# Altium schematic sheet [04] - MCU ESP32.SchDoc("[04] - MCU ESP32")
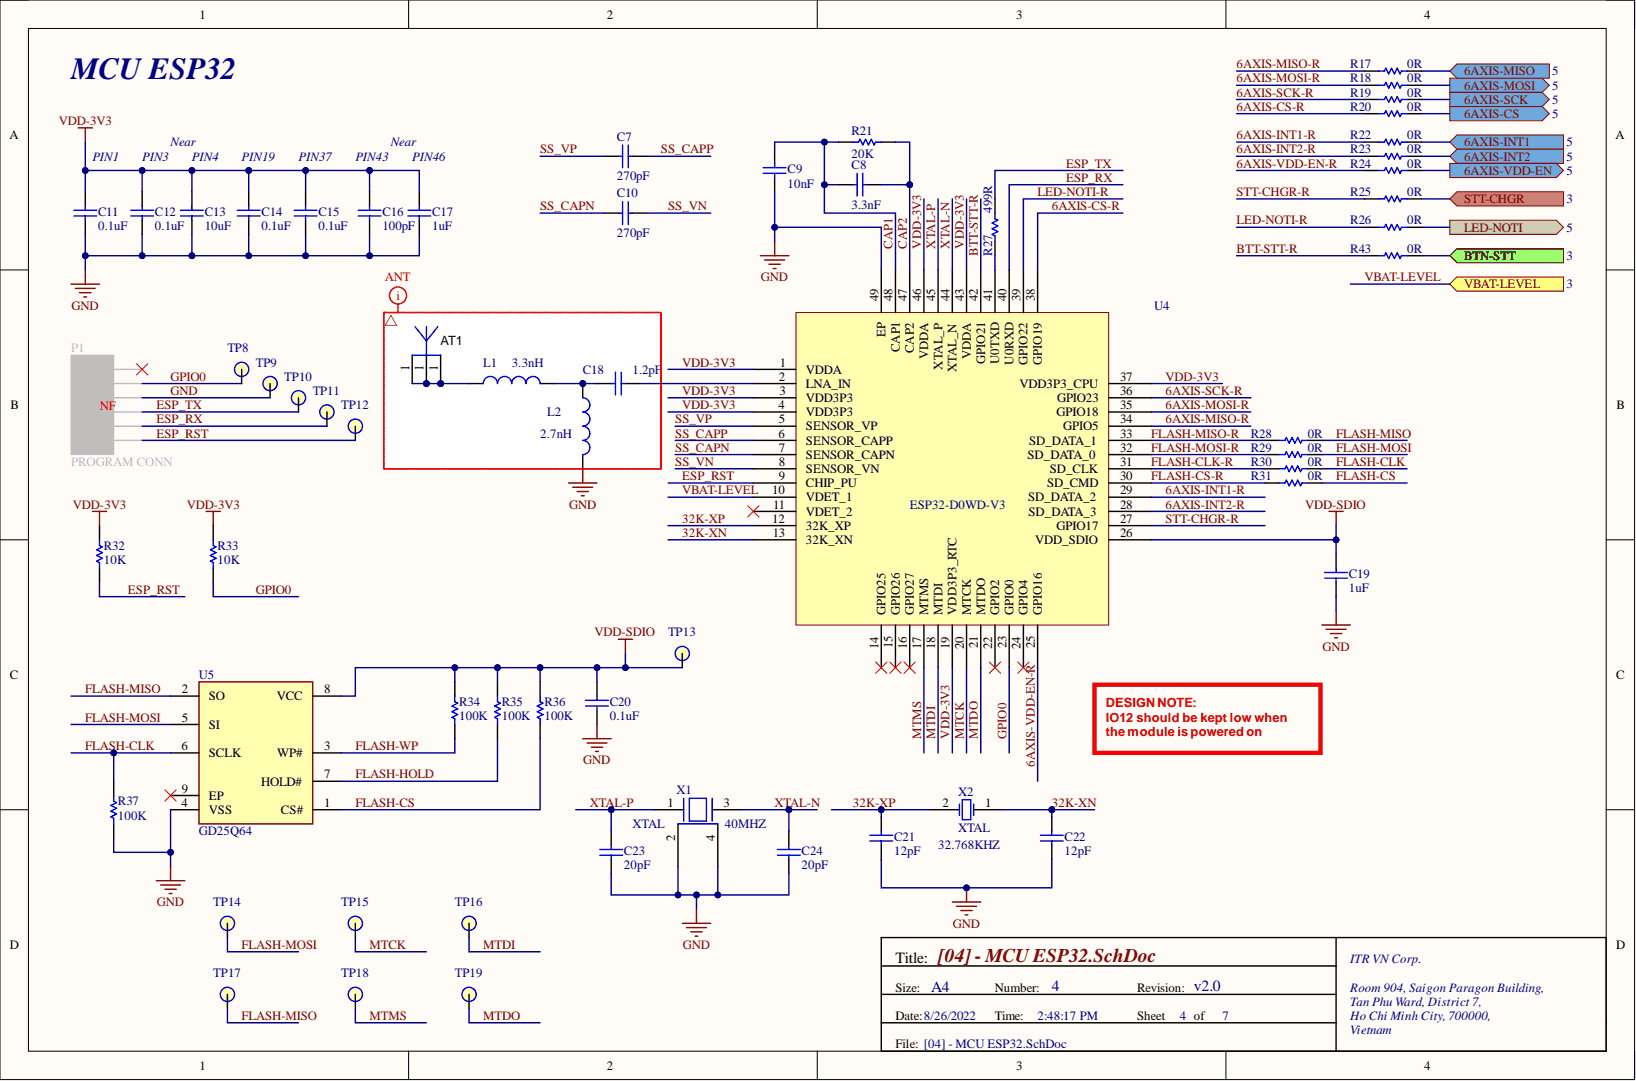
[diagram p0: [04] - MCU ESP32.SchDoc]

## Parts
AT1  2450AT18B100E  [ANT_2450AT18B100E]  (value not drawn)
C7  value 270pF  Capacitance: 270pF  [CAPC1005X55_0402]  (hidden comment: Capacitor)
C8  value 3.3nF  [CAPC1005X55_0402]  (hidden comment: Capacitor)
C9  value 10nF  [CAPC1005X55_0402]  (hidden comment: Capacitor)
C10  value 270pF  Capacitance: 270pF  [CAPC1005X55_0402]  (hidden comment: Capacitor)
C11  value 0.1uF  [CAPC1005X55_0402]  (hidden comment: Capacitor)
C12  value 0.1uF  [CAPC1005X55_0402]  (hidden comment: Capacitor)
C13  value 10uF  [CAPC1608X80_0603]  (hidden comment: Capacitor)
C14  value 0.1uF  [CAPC1005X55_0402]  (hidden comment: Capacitor)
C15  value 0.1uF  [CAPC1005X55_0402]  (hidden comment: Capacitor)
C16  value 100pF  Capacitance: 100pF  [CAPC1005X55_0402]  (hidden comment: Capacitor)
C17  value 1uF  Capacitance: 1uF  [CAPC1005X55_0402]  (hidden comment: Capacitor)
C18  value 1.2pF  Capacitance: 1.2pF  [CAPC1005X55_0402]  (hidden comment: Capacitor)
C19  value 1uF  Capacitance: 1uF  [CAPC1005X55_0402]  (hidden comment: Capacitor)
C20  value 0.1uF  [CAPC1005X55_0402]  (hidden comment: Capacitor)
C21  value 12pF  Capacitance: 12pF  [CAPC1005X55_0402]  (hidden comment: Capacitor)
C22  value 12pF  Capacitance: 12pF  [CAPC1005X55_0402]  (hidden comment: Capacitor)
C23  value 20pF  Capacitance: 20pF  [CAPC1005X55_0402]  (hidden comment: Capacitor)
C24  value 20pF  Capacitance: 20pF  [CAPC1005X55_0402]  (hidden comment: Capacitor)
L1  value 3.3nH  Inductance: 3.3nH  [INDC1005X50_0402]  (hidden comment: Inductor)
L2  value 2.7nH  Inductance: 2.7nH  [INDC1005X50_0402]  (hidden comment: Inductor)
P1  PROGRAM CONN  [Molex_530470610]
R17  value 0R  [RESC1005X40_0402]  (hidden comment: Resistor)
R18  value 0R  [RESC1005X40_0402]  (hidden comment: Resistor)
R19  value 0R  [RESC1005X40_0402]  (hidden comment: Resistor)
R20  value 0R  [RESC1005X40_0402]  (hidden comment: Resistor)
R21  value 20K  [RESC1005X40_0402]  (hidden comment: Resistor)
R22  value 0R  [RESC1005X40_0402]  (hidden comment: Resistor)
R23  value 0R  [RESC1005X40_0402]  (hidden comment: Resistor)
R24  value 0R  [RESC1005X40_0402]  (hidden comment: Resistor)
R25  value 0R  [RESC1005X40_0402]  (hidden comment: Resistor)
R26  value 0R  [RESC1005X40_0402]  (hidden comment: Resistor)
R27  value 499R  Resistance: 499R  [RESC1005X40_0402]  (hidden comment: Resistor)
R28  value 0R  [RESC1005X40_0402]  (hidden comment: Resistor)
R29  value 0R  [RESC1005X40_0402]  (hidden comment: Resistor)
R30  value 0R  [RESC1005X40_0402]  (hidden comment: Resistor)
R31  value 0R  [RESC1005X40_0402]  (hidden comment: Resistor)
R32  value 10K  [RESC1005X40_0402]  (hidden comment: Resistor)
R33  value 10K  [RESC1005X40_0402]  (hidden comment: Resistor)
R34  value 100K  [RESC1005X40_0402]  (hidden comment: Resistor)
R35  value 100K  [RESC1005X40_0402]  (hidden comment: Resistor)
R36  value 100K  [RESC1005X40_0402]  (hidden comment: Resistor)
R37  value 100K  [RESC1005X40_0402]  (hidden comment: Resistor)
R43  value 0R  [RESC1005X40_0402]  (hidden comment: Resistor)
TP8  TP  [TP100]  (no BOM)
TP9  TP  [TP100]  (no BOM)
TP10  TP  [TP100]  (no BOM)
TP11  TP  [TP100]  (no BOM)
TP12  TP  [TP100]  (no BOM)
TP13  TP  [TP100]  (no BOM)
TP14  TP  [TP100]  (no BOM)
TP15  TP  [TP100]  (no BOM)
TP16  TP  [TP100]  (no BOM)
TP17  TP  [TP100]  (no BOM)
TP18  TP  [TP100]  (no BOM)
TP19  TP  [TP100]  (no BOM)
U4  ESP32-D0WD-V3  [ESP32-D0WD-V3]
U5  GD25Q64  [WSON8(6X5)]
X1  XTAL  value 40MHZ  Load Capacitance: 20pF  [XTAL (3.2X2.5)]
X2  XTAL  value 32.768KHZ  [XTAL(2 X 1.2)MM]

## Ports
6AXIS-CS, 6AXIS-INT1, 6AXIS-INT2, 6AXIS-MISO, 6AXIS-MOSI, 6AXIS-SCK, 6AXIS-VDD-EN, BTN-STT, LED-NOTI, STT-CHGR, VBAT-LEVEL

## Nets
VDD-SDIO: C19.2 C20.2 R34.1 R35.1 R36.1 TP13.1 U4.26(VDD_SDIO) U5.8(VCC)
6AXIS-CS: R20.2 ; port 6AXIS-CS
6AXIS-CS-R: R20.1 U4.38(GPIO19)
6AXIS-INT1: R22.2 ; port 6AXIS-INT1
6AXIS-INT1-R: R22.1 U4.29(SD_DATA_2)
6AXIS-INT2: R23.2 ; port 6AXIS-INT2
6AXIS-INT2-R: R23.1 U4.28(SD_DATA_3)
6AXIS-MISO: R17.2 ; port 6AXIS-MISO
6AXIS-MISO-R: R17.1 U4.34(GPIO5)
6AXIS-MOSI: R18.2 ; port 6AXIS-MOSI
6AXIS-MOSI-R: R18.1 U4.35(GPIO18)
6AXIS-SCK: R19.2 ; port 6AXIS-SCK
6AXIS-SCK-R: R19.1 U4.36(GPIO23)
6AXIS-VDD-EN: R24.2 ; port 6AXIS-VDD-EN
6AXIS-VDD-EN-R: R24.1 U4.25(GPIO16)
32K-XN: C22.2 U4.13(32K_XN) X2.1
32K-XP: C21.2 U4.12(32K_XP) X2.2
BTN-STT: R43.2 ; port BTN-STT
BTT-STT-R: R43.1 U4.42(GPIO21)
CAP1: C8.1 C9.2 R21.1 U4.48(CAP1)
CAP2: C8.2 R21.2 U4.47(CAP2)
ESP_RST: P1.1 R32.2 TP12.1 U4.9(CHIP_PU)
ESP_RX: P1.2 TP11.1 U4.40(U0RXD)
ESP_TX: P1.3(NF) R27.2 TP10.1
FLASH-CLK: R30.2 R37.1 U5.6(SCLK)
FLASH-CLK-R: R30.1 U4.31(SD_CLK)
FLASH-CS: R31.2 R36.2 U5.1(CS#)
FLASH-CS-R: R31.1 U4.30(SD_CMD)
FLASH-HOLD: R35.2 U5.7(HOLD#)
FLASH-MISO: R28.2 TP17.1 U5.2(SO)
FLASH-MISO-R: R28.1 U4.33(SD_DATA_1)
FLASH-MOSI: R29.2 TP14.1 U5.5(SI)
FLASH-MOSI-R: R29.1 U4.32(SD_DATA_0)
FLASH-WP: R34.2 U5.3(WP#)
GND: C9.1 C11.1 C12.1 C13.1 C14.1 C15.1 C16.1 C17.1 C19.1 C20.1 C21.1 C22.1 C23.1 C24.1 L2.2 P1.4 R37.2 TP9.1 U4.49(EP) U5.4(VSS) X1.2 X1.4
GPIO0: P1.5 R33.2 TP8.1 U4.23(GPIO0)
LED-NOTI: R26.2 ; port LED-NOTI
LED-NOTI-R: R26.1 U4.39(GPIO22)
MTCK: TP15.1 U4.20(MTCK)
MTDI: TP16.1 U4.18(MTDI)
MTDO: TP19.1 U4.21(MTDO)
MTMS: TP18.1 U4.17(MTMS)
SS_CAPN: C10.1 U4.7(SENSOR_CAPN)
SS_CAPP: C7.2 U4.6(SENSOR_CAPP)
SS_VN: C10.2 U4.8(SENSOR_VN)
SS_VP: C7.1 U4.5(SENSOR_VP)
STT-CHGR: R25.2 ; port STT-CHGR
STT-CHGR-R: R25.1 U4.27(GPIO17)
VBAT-LEVEL: U4.10(VDET_1) ; port VBAT-LEVEL
VDD-3V3: C11.2 C12.2 C13.2 C14.2 C15.2 C16.2 C17.2 R32.1 R33.1 U4.1(VDDA) U4.3(VDD3P3) U4.4(VDD3P3) U4.19(VDD3P3_RTC) U4.37(VDD3P3_CPU) U4.43(VDDA) U4.46(VDDA)
XTAL-N: C24.2 U4.44(XTAL_N) X1.3
XTAL-P: C23.2 U4.45(XTAL_P) X1.1
NetAT1_1: AT1.1 L1.1
NetC18_1: C18.1 U4.2(LNA_IN)
NetC18_2: C18.2 L1.2 L2.1
NetR27_1: R27.1 U4.41(U0TXD)

Not connected: P1 6; U4 11(VDET_2) 14(GPIO25) 15(GPIO26) 16(GPIO27) 22(GPIO2) 24(GPIO4); U5 9(EP)

## Library data of the parts
AT1 = ANTENNA_DN007A: 2.4GHz PCB Antenna.  There is nothing to buy or mount.; Manufacturer 1: Johanson; Bandwidth: 100MHz; Frequency: 2.45GHz; Gain: -0.5dB; Impedance: 50R; Max Frequency: 2.5GHz; Max Power Dissipation: 3W; Number of Bands: 1; Return Loss: -9.5dB; Case/Package: CSMD; Component Kind: Standard; ComponentLink1Description: Datasheet Link; ComponentLink1URL: https://sps06.itg.ti.com/sites/KMS/crossdivteams/altium/docs/ComponentDatasheets/CC26xxEM-7IB_1_0_Layout.pdf; ComponentLink2Description: https://datasheet.ciiva.com/24025/antenna-2450at18b100-242422-24025839.pdf?src-supplier=Mouser; ComponentLink2URL: https://datasheet.ciiva.com/24025/antenna-2450at18b100-242422-24025839.pdf?src-supplier=Mouser; ComponentLink3Description: https://datasheet.ciiva.com/1124/1318299-1124918.pdf?src-supplier=Element14; ComponentLink3URL: https://datasheet.ciiva.com/1124/1318299-1124918.pdf?src-supplier=Element14; Contact Plating: Tin; Height: 0.051inch; Length: 3.2mm; Manufacturer Part Number 1: 2450AT18B100E; Max Operating Temperature: 85°C; Min Operating Temperature: -40°C; Mount: Surface Mount; Package Quantity: 1; Packaging: Tape and Reel; Power Rating: 3W; Pricing 1: 1=0.58000, 10=0.51000, 25=0.47880, 100=0.39090, 250=0.36308, 500=0.30900, 1000=0.24720 (USD); Radiation Hardening: No; REACH SVHC: No SVHC; RoHS: Yes; Stock 1: 269674; Supplier 1: Digi-Key; Supplier Part Number 1: 712-1006-1-ND; Termination: Solder; Width: 1.6mm; Library Name: symreglib-cc2640.SchLib
C7 C10 = Capacitor Semi: CAP CER 270PF 50V X7R 0402; Manufacturer 1: Murata; Manufacturer Part Number 1: GRM155R71H271KA01D; Tolerance: 10%; Case Code (Imperial): 0402; Case Code (Metric): 1005; Case/Package: 0402; Category: Ceramic Capacitors; Component Kind: Standard; ComponentLink1Description: https://datasheet.ciiva.com/18184/getdatasheetpartid-161482-18184741.pdf?src-supplier=Verical; ComponentLink1URL: https://datasheet.ciiva.com/18184/getdatasheetpartid-161482-18184741.pdf?src-supplier=Verical; ComponentLink2Description: https://datasheet.ciiva.com/130/partnumbering-e-01-130030.pdf?src-supplier=Digi-Key; ComponentLink2URL: https://datasheet.ciiva.com/130/partnumbering-e-01-130030.pdf?src-supplier=Digi-Key; ComponentLink3Description: https://datasheet.ciiva.com/130/partnumbering-e-01-130029.pdf?src-supplier=Digi-Key; ComponentLink3URL: https://datasheet.ciiva.com/130/partnumbering-e-01-130029.pdf?src-supplier=Digi-Key; ComponentLink4Description: https://datasheet.ciiva.com/130/partnumbering-e-01-130031.pdf?src-supplier=Digi-Key; ComponentLink4URL: https://datasheet.ciiva.com/130/partnumbering-e-01-130031.pdf?src-supplier=Digi-Key; Construction: Flat; Depth: 0.5mm; Dielectric: X7R; Height: 0.5mm; Lead Free: Lead Free; Length: 1mm; Library Name: Miscellaneous_Devices.SchLib; Max Operating Temperature: 125°C; Min Operating Temperature: -55°C; Mount: Surface Mount; Package Quantity: 1; Packaging: Tape and Reel; Pricing 1: 1=0.10000, 10=0.04700, 50=0.02580, 100=0.02100, 500=0.01502, 1000=0.01180, 2500=0.01072, 5000=0.00987 (USD); Radiation Hardening: No; RoHS: Yes; RoHS Compliant: Yes; Series: GRM; Stock 1: 197380; Supplier 1: Digi-Key; Supplier Part Number 1: 490-1299-1-ND; Termination: SMD/SMT; Thickness: 0.022inch; Voltage: 50V; Voltage Rating: 50V; Voltage Rating (DC): 50V; Width: 0.5mm
C8 = Capacitor Semi: CAP CER 3300PF 50V X7R 0402; Manufacturer 1: KEMET; Manufacturer Part Number 1: C0402C332K5RACTU; Tolerance: ±10%; Applications: General Purpose; Capacitance: 3300pF; Category: Capacitors; Component Kind: Standard; ComponentLink1Description: http://www.kemet.com/docfinder?Partnumber=C0402C332K5RACTU; ComponentLink1URL: http://www.kemet.com/docfinder?Partnumber=C0402C332K5RACTU; Failure Rate: -; Features: -; Height - Seated (Max): -; Lead Spacing: -; Lead Style: -; Library Name: Miscellaneous_Devices.SchLib; Mounting Type: Surface Mount, MLCC; Operating Temperature: -55°C ~ 125°C; Package / Case: 0402 (1005 Metric); Packaging: Cut Tape (CT); Part Status: Active; Pricing 1: 1=0.1, 10=0.037, 100=0.0166, 1000=0.0093, 2500=0.00845, 5000=0.00778 (USD); Ratings: -; RoHS: RoHS Compliant; Size / Dimension: 0.039" L x 0.020" W (1.00mm x 0.50mm); Stock 1: 69960; Supplier 1: Digi-Key; Supplier Part Number 1: 399-3070-1-ND; Temperature Coefficient: X7R; Thickness (Max): 0.022" (0.55mm)
C9 = Capacitor Semi: CAP CER 10000PF 50V X7R 0402; Manufacturer 1: KEMET; Manufacturer Part Number 1: C0402C103K5RACTU; Tolerance: ±10%; Applications: General Purpose; Capacitance: 10000pF; Category: Capacitors; Component Kind: Standard; ComponentLink1Description: http://www.kemet.com/docfinder?Partnumber=C0402C103K5RACTU; ComponentLink1URL: http://www.kemet.com/docfinder?Partnumber=C0402C103K5RACTU; Failure Rate: -; Features: -; Height - Seated (Max): -; Lead Spacing: -; Lead Style: -; Library Name: Miscellaneous_Devices.SchLib; Mounting Type: Surface Mount, MLCC; Operating Temperature: -55°C ~ 125°C; Package / Case: 0402 (1005 Metric); Packaging: Cut Tape (CT); Part Status: Active; Pricing 1: 1=0.1, 10=0.029, 100=0.013, 1000=0.00731, 2500=0.00664, 5000=0.00611 (USD); Ratings: -; RoHS: RoHS Compliant; Size / Dimension: 0.039" L x 0.020" W (1.00mm x 0.50mm); Stock 1: 9556099; Supplier 1: Digi-Key; Supplier Part Number 1: 399-3066-1-ND; Temperature Coefficient: X7R; Thickness (Max): 0.022" (0.55mm)
C11 C12 C14 C15 = Capacitor Semi: CAP CER 0.1UF 16V X7R 0402; Manufacturer 1: Samsung; Manufacturer Part Number 1: CL05B104KO5NNNC; Capacitance: 100nF; Material: Ceramic; Tolerance: 10%; Applications: General Purpose; Case Code (Imperial): 0402; Case Code (Metric): 1005; Case/Package: 0402; Category: Ceramic Capacitors; Component Kind: Standard; ComponentLink1Description: http://www.samsungsem.com/kr/support/product-search/mlcc/CL05B104KO5NNNC.jsp; ComponentLink1URL: http://www.samsungsem.com/kr/support/product-search/mlcc/CL05B104KO5NNNC.jsp; ComponentLink2Description: https://datasheet.ciiva.com/26920/getdatasheetpartid-359683-26920169.pdf?src-supplier=Verical; ComponentLink2URL: https://datasheet.ciiva.com/26920/getdatasheetpartid-359683-26920169.pdf?src-supplier=Verical; ComponentLink3Description: https://datasheet.ciiva.com/29198/458718-da-01-en-vs-kondensator-0402-29198423.pdf?src-supplier=Conrad; ComponentLink3URL: https://datasheet.ciiva.com/29198/458718-da-01-en-vs-kondensator-0402-29198423.pdf?src-supplier=Conrad; ComponentLink4Description: https://datasheet.ciiva.com/26309/mlcc-catalogdownload2013-eng-26309003.pdf?src-supplier=Distrelec; ComponentLink4URL: https://datasheet.ciiva.com/26309/mlcc-catalogdownload2013-eng-26309003.pdf?src-supplier=Distrelec; ComponentLink5Description: https://datasheet.ciiva.com/16208/cl05b104kq5nnnc-16208343.pdf?src-supplier=Future+Electronics; ComponentLink5URL: https://datasheet.ciiva.com/16208/cl05b104kq5nnnc-16208343.pdf?src-supplier=Future+Electronics; Construction: Flat; Contact Plating: Tin; Depth: 0.5mm; Dielectric: X7R; Features: -; Height: 0.5mm; Height - Seated (Max): -; Lead Free: Lead Free; Lead Spacing: -; Lead Style: -; Length: 1mm; Library Name: Miscellaneous_Devices.SchLib; Max Operating Temperature: 125°C; Min Operating Temperature: -55°C; Mount: Surface Mount; Mounting Type: Surface Mount, MLCC; Operating Temperature: -55°C ~ 125°C; Package Quantity: 1; Packaging: Tape and Reel; Part Status: Active; Pricing 1: 1=0.10000, 10=0.02500, 50=0.01340, 100=0.01100, 500=0.00782, 1000=0.00615, 2500=0.00559, 5000=0.00514 (USD); Radiation Hardening: No; Ratings: -; RoHS: Yes; RoHS Compliant: Yes; Series: CL; Size / Dimension: 0.039" L x 0.020" W (1.00mm x 0.50mm); Stock 1: 25921288; Supplier 1: Digi-Key; Supplier Part Number 1: 1276-1001-1-ND; Temperature Coefficient: X7R; Thickness: 0.022inch; Thickness (Max): 0.022" (0.55mm); Voltage: 16V; Voltage Rating: 16V; Voltage Rating (DC): 16V; Width: 0.02inch
C13 = Capacitor Semi: CAP CER 10UF 16V X6S 0603; Manufacturer 1: Murata Electronics North America; Manufacturer Part Number 1: GRM188C81C106MA73D; Notification: Current market demand for these product types have resulted in fluctuating and extending lead times. Lead times may differ.; Tolerance: ±20%; Applications: General Purpose; Category: Capacitors; Component Kind: Standard; ComponentLink1Description: https://www.murata.com/~/media/webrenewal/support/library/catalog/products/capacitor/mlcc/c02e.ashx?la=en-us; ComponentLink1URL: https://www.murata.com/~/media/webrenewal/support/library/catalog/products/capacitor/mlcc/c02e.ashx?la=en-us; Features: -; Height - Seated (Max): -; Lead Spacing: -; Lead Style: -; Library Name: Miscellaneous_Devices.SchLib; Mounting Type: Surface Mount, MLCC; Operating Temperature: -55°C ~ 105°C; Package / Case: 0603 (1608 Metric); Packaging: Cut Tape (CT); Part Status: Active; Pricing 1: 1=0.38, 10=0.266, 100=0.1594, 500=0.11954, 1000=0.10095 (USD); Ratings: -; RoHS: RoHS Compliant; Size / Dimension: 0.063" L x 0.032" W (1.60mm x 0.80mm); Stock 1: 735394; Supplier 1: Digi-Key; Supplier Part Number 1: 490-7198-1-ND; Temperature Coefficient: X6S; Thickness (Max): 0.032" (0.80mm)
C16 = Capacitor Semi: CAP CER 100PF 50V C0G/NP0 0402; Manufacturer 1: Murata Electronics North America; Manufacturer Part Number 1: GRM1555C1H101JA01D; Temperature Coefficient: C0G, NP0; Tolerance: ±5%; Applications: General Purpose; Category: Capacitors; Component Kind: Standard; ComponentLink1Description: http://www.murata.com/~/media/webrenewal/support/library/catalog/products/capacitor/mlcc/c02e.ashx; ComponentLink1URL: http://www.murata.com/~/media/webrenewal/support/library/catalog/products/capacitor/mlcc/c02e.ashx; Features: -; Height - Seated (Max): -; Lead Spacing: -; Lead Style: -; Library Name: Miscellaneous_Devices.SchLib; Mounting Type: Surface Mount, MLCC; Operating Temperature: -55°C ~ 125°C; Package / Case: 0402 (1005 Metric); Packaging: Cut Tape (CT); Part Status: Active; Pricing 1: 1=0.1, 10=0.013, 100=0.0059, 1000=0.00332, 2500=0.00302, 5000=0.00278 (USD); Ratings: -; RoHS: RoHS Compliant; Size / Dimension: 0.039" L x 0.020" W (1.00mm x 0.50mm); Stock 1: 4676909; Supplier 1: Digi-Key; Supplier Part Number 1: 490-5922-1-ND; Thickness (Max): 0.022" (0.55mm)
C17 = Capacitor Semi: CAP CER 1UF 16V X5R 0402; Manufacturer 1: Samsung; Manufacturer Part Number 1: CL05A105KO5NNNC; Tolerance: 10%; Applications: General Purpose; Case Code (Imperial): 0402; Case Code (Metric): 1005; Case/Package: 0402; Category: Ceramic Capacitors; Component Kind: Standard; ComponentLink1Description: https://datasheet.ciiva.com/16207/cl05a105ko5nnnc-16207571.pdf?src-supplier=Future+Electronics; ComponentLink1URL: https://datasheet.ciiva.com/16207/cl05a105ko5nnnc-16207571.pdf?src-supplier=Future+Electronics; ComponentLink2Description: https://datasheet.ciiva.com/15195/getdatasheetpartid-355272-15195629.pdf?src-supplier=Verical; ComponentLink2URL: https://datasheet.ciiva.com/15195/getdatasheetpartid-355272-15195629.pdf?src-supplier=Verical; Construction: Flat; Contact Plating: Tin; Depth: 0.5mm; Dielectric: X5R; Features: -; Height: 0.5mm; Height - Seated (Max): -; Lead Spacing: -; Lead Style: -; Length: 1mm; Library Name: Miscellaneous_Devices.SchLib; Max Operating Temperature: 85°C; Min Operating Temperature: -55°C; Mount: Surface Mount; Mounting Type: Surface Mount, MLCC; Operating Temperature: -55°C ~ 85°C; Package Quantity: 1; Packaging: Tape and Reel; Part Status: Active; Pricing 1: 1=0.10000, 10=0.04200, 50=0.02300, 100=0.01870, 500=0.01338, 1000=0.01051, 2500=0.00956, 5000=0.00879 (USD); Radiation Hardening: No; Ratings: -; RoHS: Yes; RoHS Compliant: Yes; Series: CL; Size / Dimension: 0.039" L x 0.020" W (1.00mm x 0.50mm); Stock 1: 5189685; Supplier 1: Digi-Key; Supplier Part Number 1: 1276-1067-1-ND; Temperature Coefficient: X5R; Thickness: 0.022inch; Thickness (Max): 0.022" (0.55mm); Voltage: 16V; Voltage Rating: 16V; Width: 0.02inch
C18 = Capacitor Semi: CAP CER 1.2PF 50V C0G/NP0 0402; Manufacturer 1: Johanson; Manufacturer Part Number 1: 500R07S1R2BV4T; Dielectric: C0G; Dielectric Material: Ceramic; Case Code (Imperial): 0402; Case Code (Metric): 1005; Case/Package: 0402; Component Kind: Standard; ComponentLink1Description: http://www.murata.com/~/media/webrenewal/support/library/catalog/products/capacitor/mlcc/c02e.pdf; ComponentLink1URL: http://www.murata.com/~/media/webrenewal/support/library/catalog/products/capacitor/mlcc/c02e.pdf; ComponentLink2Description: https://datasheet.ciiva.com/6263/partnumbering-e-01-6263819.pdf?src-supplier=Digi-Key; ComponentLink2URL: https://datasheet.ciiva.com/6263/partnumbering-e-01-6263819.pdf?src-supplier=Digi-Key; ComponentLink3Description: https://datasheet.ciiva.com/6263/partnumbering-e-01-6263822.pdf?src-supplier=Digi-Key; ComponentLink3URL: https://datasheet.ciiva.com/6263/partnumbering-e-01-6263822.pdf?src-supplier=Digi-Key; ComponentLink4Description: https://datasheet.ciiva.com/1461/1731432-1461669.pdf?src-supplier=Element14; ComponentLink4URL: https://datasheet.ciiva.com/1461/1731432-1461669.pdf?src-supplier=Element14; ComponentLink5Description: https://datasheet.ciiva.com/22697/1731432-22697547.pdf?src-supplier=Element14; ComponentLink5URL: https://datasheet.ciiva.com/22697/1731432-22697547.pdf?src-supplier=Element14; ComponentLink6Description: https://datasheet.ciiva.com/28495/1932950-28495023.pdf?src-supplier=Element14; ComponentLink6URL: https://datasheet.ciiva.com/28495/1932950-28495023.pdf?src-supplier=Element14; Contact Plating: Tin,Matte; Depth: 0.51mm; Height: 0.64mm; Length: 1.02mm; Library Name: Miscellaneous_Devices.SchLib; Max Operating Temperature: 125°C; Min Operating Temperature: -55°C; Number of Pins: 2; Package Quantity: 10000; Packaging: Tape and Reel; Pricing 1: 1=0.21000, 10=0.14200, 100=0.07210, 500=0.04934, 1000=0.04364, 2500=0.04174, 5000=0.03795 (USD); Radiation Hardening: No; REACH SVHC: Unknown; RoHS: Yes; Stock 1: 38574; Supplier 1: Digi-Key; Supplier Part Number 1: 712-1268-1-ND; Termination: SMD/SMT; Thickness: 0.024inch; Voltage Rating: 50V; Voltage Rating (DC): 50V; Width: 0.02inch
C19 = Capacitor Semi: CAP CER 1UF 16V X5R 0402; Manufacturer 1: Samsung; Manufacturer Part Number 1: CL05A105KO5NNNC; Symbol status: Released; Tolerance: 10%; Case Code (Imperial): 0402; Case Code (Metric): 1005; Case/Package: 0402; Category: Ceramic Capacitors; Component Kind: Standard; ComponentLink1Description: https://datasheet.ciiva.com/16207/cl05a105ko5nnnc-16207571.pdf?src-supplier=Future+Electronics; ComponentLink1URL: https://datasheet.ciiva.com/16207/cl05a105ko5nnnc-16207571.pdf?src-supplier=Future+Electronics; ComponentLink2Description: https://datasheet.ciiva.com/15195/getdatasheetpartid-355272-15195629.pdf?src-supplier=Verical; ComponentLink2URL: https://datasheet.ciiva.com/15195/getdatasheetpartid-355272-15195629.pdf?src-supplier=Verical; Construction: Flat; Contact Plating: Tin; Depth: 0.5mm; Dielectric: X5R; Height: 0.5mm; Length: 1mm; Library Name: Miscellaneous_Devices.SchLib; Max Operating Temperature: 85°C; Min Operating Temperature: -55°C; Mount: Surface Mount; Package Quantity: 1; Packaging: Tape and Reel; Pricing 1: 1=0.10000, 10=0.04200, 50=0.02300, 100=0.01870, 500=0.01338, 1000=0.01051, 2500=0.00956, 5000=0.00879 (USD); Radiation Hardening: No; RoHS: Yes; RoHS Compliant: Yes; Series: CL; Stock 1: 5189685; Supplier 1: Digi-Key; Supplier Part Number 1: 1276-1067-1-ND; Thickness: 0.022inch; Voltage: 16V; Voltage Rating: 16V; Width: 0.02inch
C20 = Capacitor Semi: CAP CER 0.1UF 16V X7R 0402; Manufacturer 1: Samsung; Manufacturer Part Number 1: CL05B104KO5NNNC; Capacitance: 100nF; Material: Ceramic; Symbol status: Released; Tolerance: 10%; Case Code (Imperial): 0402; Case Code (Metric): 1005; Case/Package: 0402; Category: Ceramic Capacitors; Component Kind: Standard; ComponentLink1Description: https://datasheet.ciiva.com/26920/getdatasheetpartid-359683-26920169.pdf?src-supplier=Verical; ComponentLink1URL: https://datasheet.ciiva.com/26920/getdatasheetpartid-359683-26920169.pdf?src-supplier=Verical; ComponentLink2Description: https://datasheet.ciiva.com/29198/458718-da-01-en-vs-kondensator-0402-29198423.pdf?src-supplier=Conrad; ComponentLink2URL: https://datasheet.ciiva.com/29198/458718-da-01-en-vs-kondensator-0402-29198423.pdf?src-supplier=Conrad; ComponentLink3Description: https://datasheet.ciiva.com/26309/mlcc-catalogdownload2013-eng-26309003.pdf?src-supplier=Distrelec; ComponentLink3URL: https://datasheet.ciiva.com/26309/mlcc-catalogdownload2013-eng-26309003.pdf?src-supplier=Distrelec; ComponentLink4Description: https://datasheet.ciiva.com/16208/cl05b104kq5nnnc-16208343.pdf?src-supplier=Future+Electronics; ComponentLink4URL: https://datasheet.ciiva.com/16208/cl05b104kq5nnnc-16208343.pdf?src-supplier=Future+Electronics; Construction: Flat; Contact Plating: Tin; Depth: 0.5mm; Dielectric: X7R; Height: 0.5mm; Lead Free: Lead Free; Length: 1mm; Library Name: Miscellaneous_Devices.SchLib; Max Operating Temperature: 125°C; Min Operating Temperature: -55°C; Mount: Surface Mount; Package Quantity: 1; Packaging: Tape and Reel; Pricing 1: 1=0.10000, 10=0.02500, 50=0.01340, 100=0.01100, 500=0.00782, 1000=0.00615, 2500=0.00559, 5000=0.00514 (USD); Radiation Hardening: No; RoHS: Yes; RoHS Compliant: Yes; Series: CL; Stock 1: 25921288; Supplier 1: Digi-Key; Supplier Part Number 1: 1276-1001-1-ND; Thickness: 0.022inch; Voltage: 16V; Voltage Rating: 16V; Voltage Rating (DC): 16V; Width: 0.02inch
C21 C22 = Capacitor Semi: CAP CER 12PF 50V C0G/NP0 0402; Manufacturer 1: KEMET; Manufacturer Part Number 1: C0402C120J5GACTU; Dielectric: C0G; Dielectric Material: Ceramic; Temperature Coefficient: 30ppm/°C; Tolerance: 5%; Case Code (Imperial): 0402; Case Code (Metric): 1005; Case/Package: 0402; Category: Ceramic Capacitors; Component Kind: Standard; ComponentLink1Description: https://datasheet.ciiva.com/7392/1372242-7392447.pdf?src-supplier=Newark; ComponentLink1URL: https://datasheet.ciiva.com/7392/1372242-7392447.pdf?src-supplier=Newark; ComponentLink2Description: https://datasheet.ciiva.com/7392/1372242-7392230.pdf?src-supplier=Newark; ComponentLink2URL: https://datasheet.ciiva.com/7392/1372242-7392230.pdf?src-supplier=Newark; ComponentLink3Description: https://datasheet.ciiva.com/7747/kem-c1003-c0g-smd-7747450.pdf?src-supplier=Element14; ComponentLink3URL: https://datasheet.ciiva.com/7747/kem-c1003-c0g-smd-7747450.pdf?src-supplier=Element14; ComponentLink4Description: https://datasheet.ciiva.com/7747/kem-c1003-c0g-smd-7747451.pdf?src-supplier=Element14; ComponentLink4URL: https://datasheet.ciiva.com/7747/kem-c1003-c0g-smd-7747451.pdf?src-supplier=Element14; ComponentLink5Description: https://datasheet.ciiva.com/28645/1789732-28645397.pdf?src-supplier=Element14; ComponentLink5URL: https://datasheet.ciiva.com/28645/1789732-28645397.pdf?src-supplier=Element14; ComponentLink6Description: https://datasheet.ciiva.com/14600/70095383-14600717.pdf?src-supplier=Allied+Electronics; ComponentLink6URL: https://datasheet.ciiva.com/14600/70095383-14600717.pdf?src-supplier=Allied+Electronics; ComponentLink7Description: https://datasheet.ciiva.com/14610/70095383-14610699.pdf?src-supplier=Allied+Electronics; ComponentLink7URL: https://datasheet.ciiva.com/14610/70095383-14610699.pdf?src-supplier=Allied+Electronics; ComponentLink8Description: https://datasheet.ciiva.com/5412/kem-c1003-c0g-smd-5412891.pdf?src-supplier=Mouser; ComponentLink8URL: https://datasheet.ciiva.com/5412/kem-c1003-c0g-smd-5412891.pdf?src-supplier=Mouser; Construction: Flat; Depth: 0.5mm; Height: 0.5mm; Lead Free: Lead Free; Lead Pitch: 0.3mm; Length: 1mm; Library Name: Miscellaneous_Devices.SchLib; Max Operating Temperature: 125°C; Min Operating Temperature: -55°C; Mount: Surface Mount,PCB; Number of Pins: 2; Package Quantity: 1; Packaging: Tape and Reel; Pricing 1: 1=0.10000, 10=0.04200, 50=0.02260, 100=0.01850, 500=0.01324, 1000=0.01040, 2500=0.00945, 5000=0.00869 (USD); Radiation Hardening: No; REACH SVHC: No SVHC; RoHS: Yes; RoHS Compliant: Yes; Series: C; Stock 1: 670668; Supplier 1: Digi-Key; Supplier Part Number 1: 399-C0402C120J5GAC7867CT-ND; Termination: SMD/SMT; Thickness: 0.022inch; Voltage: 50V; Voltage Rating: 50V; Voltage Rating (DC): 50V; Width: 0.02inch
C23 C24 = Capacitor Semi: CAP CER 20PF 50V C0G/NP0 0402; Manufacturer 1: Samsung Electro-Mechanics America, Inc.; Manufacturer Part Number 1: CL05C200JB5NNNC; Temperature Coefficient: C0G, NP0; Tolerance: ±5%; Applications: General Purpose; Category: Capacitors; Component Kind: Standard; ComponentLink1Description: http://www.samsungsem.com/kr/support/product-search/mlcc/CL05C200JB5NNNC.jsp; ComponentLink1URL: http://www.samsungsem.com/kr/support/product-search/mlcc/CL05C200JB5NNNC.jsp; Features: -; Height - Seated (Max): -; Lead Spacing: -; Lead Style: -; Library Name: Miscellaneous_Devices.SchLib; Mounting Type: Surface Mount, MLCC; Operating Temperature: -55°C ~ 125°C; Package / Case: 0402 (1005 Metric); Packaging: Cut Tape (CT); Part Status: Active; Pricing 1: 1=0.1, 10=0.013, 100=0.0057, 1000=0.00318, 2500=0.00289, 5000=0.00266 (USD); Ratings: -; RoHS: RoHS Compliant; Size / Dimension: 0.039" L x 0.020" W (1.00mm x 0.50mm); Stock 1: 392757; Supplier 1: Digi-Key; Supplier Part Number 1: 1276-1661-1-ND; Thickness (Max): 0.022" (0.55mm)
L1 = Inductor: FIXED IND 3.3NH 300MA 190 MOHM; Manufacturer 1: Johanson; Manufacturer Part Number 1: L-07C3N3SV6T; Core Material: Ceramic; DC Resistance (DCR): 190mR; Q Factor: 8; Self Resonant Frequency: 8.5GHz; Shielding: Unshielded; Test Frequency: 100MHz; Case Code (Imperial): 0402; Case Code (Metric): 1005; Case/Package: 0402; Component Kind: Standard; ComponentLink1Description: http://www.cooperindustries.com/content/dam/public/bussmann/Electronics/Resources/product-datasheets/bus-elx-ds-10248-hcma0503.pdf; ComponentLink1URL: http://www.cooperindustries.com/content/dam/public/bussmann/Electronics/Resources/product-datasheets/bus-elx-ds-10248-hcma0503.pdf; ComponentLink2Description: http://www.cooperindustries.com/content/dam/public/bussmann/Electronics/Resources/product-datasheets/bus-elx-ds-10248-hcma0503.pdf?src-supplier=Digi-Key; ComponentLink2URL: http://www.cooperindustries.com/content/dam/public/bussmann/Electronics/Resources/product-datasheets/bus-elx-ds-10248-hcma0503.pdf?src-supplier=Digi-Key; ComponentLink3Description: https://datasheet.ciiva.com/1473/1168792-1473902.pdf?src-supplier=Element14; ComponentLink3URL: https://datasheet.ciiva.com/1473/1168792-1473902.pdf?src-supplier=Element14; Current Rating: 300mA; DC Current: 300mA; Depth: 0.6mm; Height: 0.5mm; Height - Seated (Max): 0.024inch; Length: 1mm; Library Name: Miscellaneous Devices.IntLib; Max DC Current: 300mA; Max Operating Temperature: 100°C; Military Standard: Not; Min Operating Temperature: -40°C; Mount: Surface Mount; Number of Pins: 2; Package Quantity: 1; Packaging: Tape and Reel; Pricing 1: 1=0.10000, 10=0.04700, 100=0.03110, 500=0.02588, 1000=0.02174, 2500=0.01863 (USD); Radiation Hardening: No; REACH SVHC: No SVHC; RoHS: Yes; Stock 1: 111330; Supplier 1: Digi-Key; Supplier Part Number 1: 712-1416-1-ND; Termination: SMD/SMT; Width: 0.02inch
L2 = Inductor: FIXED IND 2.7NH 300MA 170 MOHM; Manufacturer 1: Johanson; Manufacturer Part Number 1: L-07C2N7SV6T; Core Material: Ceramic; DC Resistance (DCR): 170mR; Q Factor: 8; Self Resonant Frequency: 9.5GHz; Shielding: Unshielded; Test Frequency: 100MHz; Case Code (Imperial): 0402; Case Code (Metric): 1005; Case/Package: 0402; Component Kind: Standard; ComponentLink1Description: http://www.cooperindustries.com/content/dam/public/bussmann/Electronics/Resources/product-datasheets/bus-elx-ds-10248-hcma0503.pdf; ComponentLink1URL: http://www.cooperindustries.com/content/dam/public/bussmann/Electronics/Resources/product-datasheets/bus-elx-ds-10248-hcma0503.pdf; ComponentLink2Description: http://www.cooperindustries.com/content/dam/public/bussmann/Electronics/Resources/product-datasheets/bus-elx-ds-10248-hcma0503.pdf?src-supplier=Digi-Key; ComponentLink2URL: http://www.cooperindustries.com/content/dam/public/bussmann/Electronics/Resources/product-datasheets/bus-elx-ds-10248-hcma0503.pdf?src-supplier=Digi-Key; ComponentLink3Description: https://datasheet.ciiva.com/1473/1168792-1473898.pdf?src-supplier=Element14; ComponentLink3URL: https://datasheet.ciiva.com/1473/1168792-1473898.pdf?src-supplier=Element14; Contact Plating: Tin,Matte; Current Rating: 300mA; DC Current: 300mA; Depth: 0.6mm; Height: 0.5mm; Height - Seated (Max): 0.024inch; Length: 1mm; Library Name: Miscellaneous Devices.IntLib; Max DC Current: 300mA; Max Operating Temperature: 100°C; Military Standard: Not; Min Operating Temperature: -40°C; Mount: Surface Mount; Number of Pins: 2; Package Quantity: 1; Packaging: Tape and Reel; Pricing 1: 1=0.10000, 10=0.04700, 100=0.03110, 500=0.02588, 1000=0.02174, 2500=0.01863 (USD); Radiation Hardening: No; REACH SVHC: No SVHC; RoHS: Yes; Stock 1: 124005; Supplier 1: Digi-Key; Supplier Part Number 1: 712-1415-1-ND; Termination: SMD/SMT; Weight: 2.3E-05oz; Width: 0.02inch
P1 = Header 6: MOLEX - 53047-0610 - Wire-To-Board Connector, Vertical, PicoBlade 53047 Series, Through Hole, Header, 6, 1.25 mm RoHS Compliant: Yes; Body Plating: Tin; BOM Type: A; Contact Resistance: 20mR; Current: 1A; Gender: Male; Glow Wire Compliant: Non-compliant; Insulation Resistance: 100MR; Max Voltage Rating (AC): 125V; Number of Circuits: 6; Pitch: 1.25mm; Category: Headers and Wire Housings; Color: Natural; Component Kind: Standard; ComponentLink10Description: https://datasheet.ciiva.com/30072/347010530470610-pcb-headers-30072455.pdf?src-supplier=Onlinecomponents.com; ComponentLink10URL: https://datasheet.ciiva.com/30072/347010530470610-pcb-headers-30072455.pdf?src-supplier=Onlinecomponents.com; ComponentLink11Description: https://datasheet.ciiva.com/16658/0530470610-pcb-headers-16658421.pdf?src-supplier=Avnet+Europe; ComponentLink11URL: https://datasheet.ciiva.com/16658/0530470610-pcb-headers-16658421.pdf?src-supplier=Avnet+Europe; ComponentLink12Description: https://datasheet.ciiva.com/16999/70266941-16999996.pdf?src-supplier=Allied+Electronics; ComponentLink12URL: https://datasheet.ciiva.com/16999/70266941-16999996.pdf?src-supplier=Allied+Electronics; ComponentLink13Description: https://datasheet.ciiva.com/14156/ge116819-116832-e-14156237.pdf?src-supplier=Distrelec; ComponentLink13URL: https://datasheet.ciiva.com/14156/ge116819-116832-e-14156237.pdf?src-supplier=Distrelec; ComponentLink1Description: https://datasheet.ciiva.com/26894/getdatasheetpartid-424647-26894806.pdf?src-supplier=Verical; ComponentLink1URL: https://datasheet.ciiva.com/26894/getdatasheetpartid-424647-26894806.pdf?src-supplier=Verical; ComponentLink2Description: https://datasheet.ciiva.com/26894/getdatasheetpartid-641012-26894489.pdf?src-supplier=Verical; ComponentLink2URL: https://datasheet.ciiva.com/26894/getdatasheetpartid-641012-26894489.pdf?src-supplier=Verical; ComponentLink3Description: https://datasheet.ciiva.com/20207/523653-20207177.pdf?src-supplier=Rapid+Electronics; ComponentLink3URL: https://datasheet.ciiva.com/20207/523653-20207177.pdf?src-supplier=Rapid+Electronics; ComponentLink4Description: https://datasheet.ciiva.com/16397/b6b-xh-alfsn-16397116.pdf?src-supplier=Future+Electronics; ComponentLink4URL: https://datasheet.ciiva.com/16397/b6b-xh-alfsn-16397116.pdf?src-supplier=Future+Electronics; ComponentLink5Description: https://datasheet.ciiva.com/712/exh-712511.pdf?src-supplier=Digi-Key; ComponentLink5URL: https://datasheet.ciiva.com/712/exh-712511.pdf?src-supplier=Digi-Key; ComponentLink6Description: https://datasheet.ciiva.com/11748/0900766b8024e2fd-11748275.pdf?src-supplier=RSComponents; ComponentLink6URL: https://datasheet.ciiva.com/11748/0900766b8024e2fd-11748275.pdf?src-supplier=RSComponents; ComponentLink7Description: https://datasheet.ciiva.com/9468/0530470610-pcb-headers-9468500.pdf?src-supplier=Avnet; ComponentLink7URL: https://datasheet.ciiva.com/9468/0530470610-pcb-headers-9468500.pdf?src-supplier=Avnet; ComponentLink8Description: https://datasheet.ciiva.com/27054/1932491-27054997.pdf?src-supplier=Jameco; ComponentLink8URL: https://datasheet.ciiva.com/27054/1932491-27054997.pdf?src-supplier=Jameco; ComponentLink9Description: https://datasheet.ciiva.com/5154/0530470610-pcb-headers-170929-5154678.pdf?src-supplier=Mouser; ComponentLink9URL: https://datasheet.ciiva.com/5154/0530470610-pcb-headers-170929-5154678.pdf?src-supplier=Mouser; Contact Material: Bronze,Tin; Contact Plating: Tin; Current Rating: 1A; Flammability Rating: UL94 V-0; Housing Color: Natural; Housing Material: Polyamide; Lead Free: Lead Free; Lead Pitch: 1.25mm; Library Name: Miscellaneous Connectors.IntLib; Manufacturer 1: Molex; Manufacturer Part Number 1: 53047-0610; Max Operating Temperature: 85°C; Min Operating Temperature: -40°C; Mount: Through Hole; Number of Contacts: 6; Number of Poles: 6; Number of Positions: 6; Number of Rows: 1; Orientation: Vertical; Package Quantity: 2000; Packaging: Tray; Plating: Tin; Pricing 1: 1=0.39000, 10=0.36800, 100=0.25730, 500=0.22050, 1000=0.18375, 5000=0.15750, 10000=0.14700 (USD); Radiation Hardening: No; REACH SVHC: Unknown; RoHS: Yes; Signal Integrity: Connector; Stock 1: 55121; Supplier 1: Digi-Key; Supplier Part Number 1: WM1735-ND; Termination: Through Hole; Voltage: 125V; Voltage Rating: 125V; Voltage Rating (AC): 125V; Voltage Rating (DC): 125V; Working Voltage: 125V
R17-R20 R22-R26 R28-R31 R43 = Res1: PANASONIC - ERJ2GE0R00X - RES, 0R0, 0.1W, 0402, REEL; Manufacturer Part Number 1: ERJ-2GE0R00X; BOM Type: A; Max Power Dissipation: 100mW; Resistance: 0mR; Temperature Coefficient: 600ppm/°C; Tolerance: 5%; Voltage Rating: 50V; Case Code (Imperial): 0402; Case Code (Metric): 1005; Case/Package: 0402; Category: Chip SMD Resistors; Component Kind: Standard; ComponentLink1Description: https://datasheet.ciiva.com/11821/0900766b80f806dd-11821176.pdf?src-supplier=RSComponents; ComponentLink1URL: https://datasheet.ciiva.com/11821/0900766b80f806dd-11821176.pdf?src-supplier=RSComponents; ComponentLink2Description: https://datasheet.ciiva.com/48531/aoa0000ce1-48531077.pdf?src-supplier=Avnet; ComponentLink2URL: https://datasheet.ciiva.com/48531/aoa0000ce1-48531077.pdf?src-supplier=Avnet; ComponentLink3Description: https://datasheet.ciiva.com/16783/70260739-16783734.pdf?src-supplier=Allied+Electronics; ComponentLink3URL: https://datasheet.ciiva.com/16783/70260739-16783734.pdf?src-supplier=Allied+Electronics; ComponentLink4Description: https://datasheet.ciiva.com/17215/aoa0000ce1-17215386.pdf?src-supplier=Avnet+Europe; ComponentLink4URL: https://datasheet.ciiva.com/17215/aoa0000ce1-17215386.pdf?src-supplier=Avnet+Europe; ComponentLink5Description: https://datasheet.ciiva.com/43460/1678796-43460347.pdf?src-supplier=Farnell; ComponentLink5URL: https://datasheet.ciiva.com/43460/1678796-43460347.pdf?src-supplier=Farnell; ComponentLink6Description: https://datasheet.ciiva.com/5959/1678796-5959692.pdf?src-supplier=Farnell; ComponentLink6URL: https://datasheet.ciiva.com/5959/1678796-5959692.pdf?src-supplier=Farnell; ComponentLink7Description: https://datasheet.ciiva.com/30097/4aoa0000ce1-30097116.pdf?src-supplier=Onlinecomponents.com; ComponentLink7URL: https://datasheet.ciiva.com/30097/4aoa0000ce1-30097116.pdf?src-supplier=Onlinecomponents.com; ComponentLink8Description: https://datasheet.ciiva.com/28695/1678796-28695758.pdf?src-supplier=Element14; ComponentLink8URL: https://datasheet.ciiva.com/28695/1678796-28695758.pdf?src-supplier=Element14; ComponentLink9Description: https://datasheet.ciiva.com/46389/1678796-46389518.pdf?src-supplier=Element14; ComponentLink9URL: https://datasheet.ciiva.com/46389/1678796-46389518.pdf?src-supplier=Element14; Contact Plating: Tin; Depth: 0.5mm; Features: Automotive AEC-Q200; Height: 0.35mm; Lead Free: Lead Free; Length: 1mm; Library Name: Miscellaneous Devices.IntLib; Manufacturer 1: Panasonic; Max Operating Temperature: 155°C; Military Standard: Not; Min Operating Temperature: -55°C; Mount: Surface Mount; Number of Terminations: 2; Package Quantity: 1; Packaging: Tape and Reel; Power Rating: 100mW; Pricing 1: 1=0.10000, 10=0.01900, 25=0.01360, 50=0.01040, 100=0.00770, 250=0.00584, 500=0.00468, 1000=0.00345, 5000=0.00247 (USD); Radiation Hardening: No; REACH SVHC: No SVHC; Resistor Type: Thick Film; RoHS: Yes; Signal Integrity: Res; Simulation: RESISTOR; Stock 1: 15318658; Supplier 1: Digi-Key; Supplier Part Number 1: P0.0JCT-ND; Termination: SMD/SMT; Voltage Rating (DC): 50V; Width: 0.02inch
R21 = Resistor 1: RES SMD 20K OHM 1% 1/10W 0402; Manufacturer 1: Panasonic Electronic Components; Manufacturer Part Number 1: ERJ-2RKF2002X; Power (Watts): 0.1W, 1/10W; Temperature Coefficient: ±100ppm/°C; Category: Resistors; Component Kind: Standard; ComponentLink1Description: https://industrial.panasonic.com/cdbs/www-data/pdf/RDA0000/AOA0000C283.pdf; ComponentLink1URL: https://industrial.panasonic.com/cdbs/www-data/pdf/RDA0000/AOA0000C283.pdf; Composition: Thick Film; Failure Rate: -; Features: Automotive AEC-Q200; Height - Seated (Max): 0.016" (0.40mm); Library Name: Miscellaneous_Devices.SchLib; Operating Temperature: -55°C ~ 155°C; Package / Case: 0402 (1005 Metric); Packaging: Cut Tape (CT); Part Status: Active; Pricing 1: 1=0.1, 10=0.026, 100=0.0105, 1000=0.00473, 2500=0.0041, 5000=0.00339 (USD); Resistance (Ohms): 20k; RoHS: RoHS Compliant; Size / Dimension: 0.039" L x 0.020" W (1.00mm x 0.50mm); Stock 1: 524119; Supplier 1: Digi-Key; Supplier Device Package: 0402; Supplier Part Number 1: P20.0KLCT-ND; Tolerance: ±1%
R27 = Resistor: Res Thick Film 0402 499 Ohm 1% 1/10W ±100ppm/°C Molded SMD Punched Carrier T/R; Manufacturer 1: Panasonic; Manufacturer Part Number 1: ERJ-2RKF4990X; Max Power Dissipation: 100mW; Voltage Rating: 50V; Case Code (Imperial): 0402; Case Code (Metric): 1005; Case/Package: 0402; Category: Chip SMD Resistors; Component Kind: Standard; ComponentLink1Description: https://datasheet.ciiva.com/6201/426256-6201373.pdf?src-supplier=Farnell; ComponentLink1URL: https://datasheet.ciiva.com/6201/426256-6201373.pdf?src-supplier=Farnell; ComponentLink2Description: https://datasheet.ciiva.com/46377/426256-46377550.pdf?src-supplier=Element14; ComponentLink2URL: https://datasheet.ciiva.com/46377/426256-46377550.pdf?src-supplier=Element14; ComponentLink3Description: https://datasheet.ciiva.com/22749/1678782-22749610.pdf?src-supplier=Element14; ComponentLink3URL: https://datasheet.ciiva.com/22749/1678782-22749610.pdf?src-supplier=Element14; ComponentLink4Description: https://datasheet.ciiva.com/28695/1678782-28695781.pdf?src-supplier=Element14; ComponentLink4URL: https://datasheet.ciiva.com/28695/1678782-28695781.pdf?src-supplier=Element14; ComponentLink5Description: https://datasheet.ciiva.com/16766/70260748-16766015.pdf?src-supplier=Allied+Electronics; ComponentLink5URL: https://datasheet.ciiva.com/16766/70260748-16766015.pdf?src-supplier=Allied+Electronics; ComponentLink6Description: https://datasheet.ciiva.com/16765/70260748-16765054.pdf?src-supplier=Allied+Electronics; ComponentLink6URL: https://datasheet.ciiva.com/16765/70260748-16765054.pdf?src-supplier=Allied+Electronics; ComponentLink7Description: https://datasheet.ciiva.com/9181/aoa0000ce2-9181461.pdf?src-supplier=Avnet; ComponentLink7URL: https://datasheet.ciiva.com/9181/aoa0000ce2-9181461.pdf?src-supplier=Avnet; ComponentLink8Description: https://datasheet.ciiva.com/16508/aoa0000ce2-16508382.pdf?src-supplier=Future+Electronics; ComponentLink8URL: https://datasheet.ciiva.com/16508/aoa0000ce2-16508382.pdf?src-supplier=Future+Electronics; Construction: Rectangular; Contact Plating: Tin; Depth: 0.5mm; Features: Automotive AEC-Q200; Height: 0.35mm; Lead Free: Lead Free; Length: 1mm; Library Name: Miscellaneous_Devices.SchLib; Max Operating Temperature: 155°C; Military Standard: Not; Min Operating Temperature: -55°C; Mount: Surface Mount; Number of Terminations: 2; Package Quantity: 1; Packaging: Tape and Reel; Power Rating: 100mW; Pricing 1: 1=0.10000, 10=0.03500, 100=0.01420, 1000=0.00636, 2500=0.00552, 5000=0.00456 (USD); REACH SVHC: No SVHC; Resistance Tolerance: 1%; Resistor Type: Thick Film; RoHS: Yes; RoHS Compliant: Yes; Series: ERJ; Size Code: 0402; Stock 1: 40000; Supplier 1: Digi-Key; Supplier Part Number 1: P499LDKR-ND; Technology: THICK FILM; Temperature Coefficient: 100ppm/°C; Termination: SMD/SMT; Tolerance: 1%; Voltage Rating (DC): 50V; Width: 0.02inch
R32 R33 = Res1: RES SMD 10K OHM 5% 1/10W 0402; Manufacturer 1: Panasonic; Manufacturer Part Number 1: ERJ-2GEJ103X; BOM Type: A; Max Power Dissipation: 100mW; Resistance: 10kR; Temperature Coefficient: 200ppm/°C; Voltage Rating: 50V; Case Code (Imperial): 0402; Case Code (Metric): 1005; Case/Package: 0402; Category: Chip SMD Resistors; Component Kind: Standard; ComponentLink1Description: https://datasheet.ciiva.com/11821/0900766b80f806dd-11821170.pdf?src-supplier=RSComponents; ComponentLink1URL: https://datasheet.ciiva.com/11821/0900766b80f806dd-11821170.pdf?src-supplier=RSComponents; ComponentLink2Description: https://datasheet.ciiva.com/27055/1935721-27055112.pdf?src-supplier=Jameco; ComponentLink2URL: https://datasheet.ciiva.com/27055/1935721-27055112.pdf?src-supplier=Jameco; ComponentLink3Description: https://datasheet.ciiva.com/17212/aoa0000ce1-17212101.pdf?src-supplier=Avnet+Europe; ComponentLink3URL: https://datasheet.ciiva.com/17212/aoa0000ce1-17212101.pdf?src-supplier=Avnet+Europe; ComponentLink4Description: https://datasheet.ciiva.com/46371/1678796-46371910.pdf?src-supplier=Element14; ComponentLink4URL: https://datasheet.ciiva.com/46371/1678796-46371910.pdf?src-supplier=Element14; ComponentLink5Description: https://datasheet.ciiva.com/24070/aoa0000ce1-37422-24070679.pdf?src-supplier=Mouser; ComponentLink5URL: https://datasheet.ciiva.com/24070/aoa0000ce1-37422-24070679.pdf?src-supplier=Mouser; ComponentLink6Description: https://datasheet.ciiva.com/43460/1678796-43460391.pdf?src-supplier=Farnell; ComponentLink6URL: https://datasheet.ciiva.com/43460/1678796-43460391.pdf?src-supplier=Farnell; ComponentLink7Description: https://datasheet.ciiva.com/16774/70260739-16774504.pdf?src-supplier=Allied+Electronics; ComponentLink7URL: https://datasheet.ciiva.com/16774/70260739-16774504.pdf?src-supplier=Allied+Electronics; Contact Plating: Tin; Depth: 0.5mm; Features: Automotive AEC-Q200; Height: 0.35mm; LatestRevisionDate: 17-Jul-2002; LatestRevisionNote: Re-released for DXP Platform.; Lead Free: Lead Free; Length: 1mm; Library Name: Miscellaneous Devices.IntLib; Max Operating Temperature: 155°C; Military Standard: Not; Min Operating Temperature: -55°C; Mount: Surface Mount; Number of Terminations: 2; Package Quantity: 1; PackageDescription: Axial Device, Thru-Hole; 2 Leads; 0.3 in Pin Spacing; PackageReference: AXIAL-0.3; Packaging: Cut Tape; Power Rating: 100mW; Pricing 1: 1=0.10000, 10=0.01900, 100=0.00770, 1000=0.00345, 2500=0.00299, 5000=0.00247 (USD); Published: 8-Jun-2000; Radiation Hardening: No; REACH SVHC: No SVHC; Resistor Type: Thick Film; RoHS: Yes; Signal Integrity: Res; Simulation: RESISTOR; Stock 1: 2048955; Supplier 1: Digi-Key; Supplier Part Number 1: P10KJCT-ND; Termination: SMD/SMT; Tolerance: 5%; Voltage Rating (DC): 50V; Width: 0.02inch; Publisher: Altium Limited
R34-R37 = Resistor 1: RES SMD 100K OHM 1% 1/10W 0402; Manufacturer 1: Panasonic; Manufacturer Part Number 1: ERJ-2RKF1003X; Max Power Dissipation: 100mW; Power (Watts): 0.1W, 1/10W; Resistance: 100kR; Temperature Coefficient: 100ppm/°C; Voltage Rating: 50V; Case Code (Imperial): 0402; Case Code (Metric): 1005; Case/Package: 0402; Category: Chip SMD Resistors; Component Kind: Standard; Composition: Thick Film; Contact Plating: Tin; Depth: 0.5mm; Failure Rate: -; Features: Automotive AEC-Q200; Height: 0.35mm; Height - Seated (Max): 0.016" (0.40mm); Lead Free: Lead Free; Length: 1mm; Library Name: Miscellaneous_Devices.SchLib; Max Operating Temperature: 155°C; Military Standard: Not; Min Operating Temperature: -55°C; Mount: Surface Mount; Number of Pins: 2; Number of Terminations: 2; Operating Temperature: -55°C ~ 155°C; Package Quantity: 10000; Packaging: Cut Tape; Part Status: Active; Power Rating: 100mW; Pricing 1: 1=0.10000, 10=0.03500, 100=0.01420, 1000=0.00636, 2500=0.00552, 5000=0.00456 (USD); Radiation Hardening: No; REACH SVHC: No SVHC; Resistance (Ohms): 100k; Resistor Type: Thick Film; RoHS: Yes; RoHS Compliant: Yes; Series: ERJ-2Rx; Size / Dimension: 0.039" L x 0.020" W (1.00mm x 0.50mm); Stock 1: 99827; Supplier 1: Digi-Key; Supplier Device Package: 0402; Supplier Part Number 1: P100KLCT-ND; Technology: THICK FILM; Termination: SMD/SMT; Tolerance: 1%; Voltage Rating (DC): 50V; Weight: 0.000388oz; Width: 0.5mm
  R34-R36: ComponentLink10Description: https://datasheet.ciiva.com/9186/aoa0000ce2-9186687.pdf?src-supplier=Avnet; ComponentLink10URL: https://datasheet.ciiva.com/9186/aoa0000ce2-9186687.pdf?src-supplier=Avnet; ComponentLink11Description: https://datasheet.ciiva.com/16440/aoa0000ce2-16440217.pdf?src-supplier=Future+Electronics; ComponentLink11URL: https://datasheet.ciiva.com/16440/aoa0000ce2-16440217.pdf?src-supplier=Future+Electronics; ComponentLink12Description: https://datasheet.ciiva.com/48531/aoa0000ce2-48531070.pdf?src-supplier=Avnet; ComponentLink12URL: https://datasheet.ciiva.com/48531/aoa0000ce2-48531070.pdf?src-supplier=Avnet; ComponentLink13Description: https://datasheet.ciiva.com/30245/aoa0000ce2-30245691.pdf?src-supplier=Onlinecomponents.com; ComponentLink13URL: https://datasheet.ciiva.com/30245/aoa0000ce2-30245691.pdf?src-supplier=Onlinecomponents.com; ComponentLink1Description: https://industrial.panasonic.com/cdbs/www-data/pdf/RDA0000/AOA0000C283.pdf; ComponentLink1URL: https://industrial.panasonic.com/cdbs/www-data/pdf/RDA0000/AOA0000C283.pdf; ComponentLink2Description: https://datasheet.ciiva.com/34303/1987038-34303550.pdf?src-supplier=Jameco; ComponentLink2URL: https://datasheet.ciiva.com/34303/1987038-34303550.pdf?src-supplier=Jameco; ComponentLink3Description: https://datasheet.ciiva.com/11821/0900766b80f80784-11821175.pdf?src-supplier=RSComponents; ComponentLink3URL: https://datasheet.ciiva.com/11821/0900766b80f80784-11821175.pdf?src-supplier=RSComponents; ComponentLink4Description: https://datasheet.ciiva.com/7830/aoa0000ce2-7830725.pdf?src-supplier=Element14; ComponentLink4URL: https://datasheet.ciiva.com/7830/aoa0000ce2-7830725.pdf?src-supplier=Element14; ComponentLink5Description: https://datasheet.ciiva.com/7830/426256-7830974.pdf?src-supplier=Element14; ComponentLink5URL: https://datasheet.ciiva.com/7830/426256-7830974.pdf?src-supplier=Element14; ComponentLink6Description: https://datasheet.ciiva.com/43467/1678782-43467480.pdf?src-supplier=Farnell; ComponentLink6URL: https://datasheet.ciiva.com/43467/1678782-43467480.pdf?src-supplier=Farnell; ComponentLink7Description: https://datasheet.ciiva.com/22723/426256-22723902.pdf?src-supplier=Element14; ComponentLink7URL: https://datasheet.ciiva.com/22723/426256-22723902.pdf?src-supplier=Element14; ComponentLink8Description: https://datasheet.ciiva.com/16778/70260748-16778055.pdf?src-supplier=Allied+Electronics; ComponentLink8URL: https://datasheet.ciiva.com/16778/70260748-16778055.pdf?src-supplier=Allied+Electronics; ComponentLink9Description: https://datasheet.ciiva.com/16784/70260748-16784190.pdf?src-supplier=Allied+Electronics; ComponentLink9URL: https://datasheet.ciiva.com/16784/70260748-16784190.pdf?src-supplier=Allied+Electronics
  R37: ComponentLink10Description: https://datasheet.ciiva.com/16440/aoa0000ce2-16440217.pdf?src-supplier=Future+Electronics; ComponentLink10URL: https://datasheet.ciiva.com/16440/aoa0000ce2-16440217.pdf?src-supplier=Future+Electronics; ComponentLink11Description: https://datasheet.ciiva.com/48531/aoa0000ce2-48531070.pdf?src-supplier=Avnet; ComponentLink11URL: https://datasheet.ciiva.com/48531/aoa0000ce2-48531070.pdf?src-supplier=Avnet; ComponentLink12Description: https://datasheet.ciiva.com/30245/aoa0000ce2-30245691.pdf?src-supplier=Onlinecomponents.com; ComponentLink12URL: https://datasheet.ciiva.com/30245/aoa0000ce2-30245691.pdf?src-supplier=Onlinecomponents.com; ComponentLink1Description: https://datasheet.ciiva.com/34303/1987038-34303550.pdf?src-supplier=Jameco; ComponentLink1URL: https://datasheet.ciiva.com/34303/1987038-34303550.pdf?src-supplier=Jameco; ComponentLink2Description: https://datasheet.ciiva.com/11821/0900766b80f80784-11821175.pdf?src-supplier=RSComponents; ComponentLink2URL: https://datasheet.ciiva.com/11821/0900766b80f80784-11821175.pdf?src-supplier=RSComponents; ComponentLink3Description: https://datasheet.ciiva.com/7830/aoa0000ce2-7830725.pdf?src-supplier=Element14; ComponentLink3URL: https://datasheet.ciiva.com/7830/aoa0000ce2-7830725.pdf?src-supplier=Element14; ComponentLink4Description: https://datasheet.ciiva.com/7830/426256-7830974.pdf?src-supplier=Element14; ComponentLink4URL: https://datasheet.ciiva.com/7830/426256-7830974.pdf?src-supplier=Element14; ComponentLink5Description: https://datasheet.ciiva.com/43467/1678782-43467480.pdf?src-supplier=Farnell; ComponentLink5URL: https://datasheet.ciiva.com/43467/1678782-43467480.pdf?src-supplier=Farnell; ComponentLink6Description: https://datasheet.ciiva.com/22723/426256-22723902.pdf?src-supplier=Element14; ComponentLink6URL: https://datasheet.ciiva.com/22723/426256-22723902.pdf?src-supplier=Element14; ComponentLink7Description: https://datasheet.ciiva.com/16778/70260748-16778055.pdf?src-supplier=Allied+Electronics; ComponentLink7URL: https://datasheet.ciiva.com/16778/70260748-16778055.pdf?src-supplier=Allied+Electronics; ComponentLink8Description: https://datasheet.ciiva.com/16784/70260748-16784190.pdf?src-supplier=Allied+Electronics; ComponentLink8URL: https://datasheet.ciiva.com/16784/70260748-16784190.pdf?src-supplier=Allied+Electronics; ComponentLink9Description: https://datasheet.ciiva.com/9186/aoa0000ce2-9186687.pdf?src-supplier=Avnet; ComponentLink9URL: https://datasheet.ciiva.com/9186/aoa0000ce2-9186687.pdf?src-supplier=Avnet
TP8-TP19 = TEST_POINT: Library Name: Miscellaneous_Devices.SchLib
U4 = ESP32-D0WD-V3: WIFI-Bluetooth Combo Chip 2412MHz to 2483MHz 3.3V 48-Pin QFN; Manufacturer 1: Espressif Systems; Category: RF Receivers, Transceivers; Component Kind: Standard; ComponentLink1Description: https://www.digikey.co.nz/en/products/detail/espressif-systems/ESP32-D0WD-V3/11657613; ComponentLink1URL: https://www.digikey.co.nz/en/products/detail/espressif-systems/ESP32-D0WD-V3/11657613; Manufacturer Part Number 1: ESP32-D0WD-V3; Pricing 1: 1=1.80000 (USD); RoHS: No; Stock 1: 14838; Supplier 1: Digi-Key; Supplier Part Number 1: 1904-ESP32-D0WD-V3CT-ND; Library Name: TENA_V2.0.SchLib
U5 = GD25Q36: 64Mb SPI NOR Flash 2.7V-3.6V SOP8 (208mm); Manufacturer 1: GigaDevice; Manufacturer Part Number 1: GD25Q64CWIGR; Category: Memory; Component Kind: Standard; ComponentLink1Description: https://www.digikey.co.nz/en/products/detail/gigadevice-semiconductor-hk-limited/GD25Q64CWIGR/9484710?s=N4IgjCBcoBwAxyqAxlAZgQwDYGcCmANCAPZQDa4ATHAAQCyAEgF4gC6RADgC5QgCqAOwCWXAPJo6eDDgCuAJzwgAvkTCUAnBGghUkTLkIlyIDQFYALDADMbTj0ggAylzlCBAc2UqTxgOIARSlMARQA2czYlIA; ComponentLink1URL: https://www.digikey.co.nz/en/products/detail/gigadevice-semiconductor-hk-limited/GD25Q64CWIGR/9484710?s=N4IgjCBcoBwAxyqAxlAZgQwDYGcCmANCAPZQDa4ATHAAQCyAEgF4gC6RADgC5QgCqAOwCWXAPJo6eDDgCuAJzwgAvkTCUAnBGghUkTLkIlyIDQFYALDADMbTj0ggAylzlCBAc2UqTxgOIARSlMARQA2czYlIA; Pricing 1: 1=1.48000, 10=1.35400, 25=1.31760, 50=1.31060, 100=1.17160, 250=1.16820, 500=1.15056, 1000=1.10172 (USD); RoHS: No; Stock 1: 59685; Supplier 1: Digi-Key; Supplier Part Number 1: 1970-1045-1-ND; Library Name: TENA_V2.0.SchLib
X1 = XTAL2: CRYSTAL 40.0000MHZ 20PF SMD; Manufacturer 1: ECS Inc.; Manufacturer Part Number 1: ECS-400-20-33-TR; ESR (Equivalent Series Resistance): 40 Ohm; Frequency Stability: ±50ppm; Operating Mode: Fundamental; Category: Crystals, Oscillators, Resonators; Component Kind: Standard; ComponentLink1Description: http://www.ecsxtal.com/store/pdf/ecx-32.pdf; ComponentLink1URL: http://www.ecsxtal.com/store/pdf/ecx-32.pdf; Frequency: 40MHz; Frequency Tolerance: ±50ppm; Height - Seated (Max): 0.031" (0.80mm); Library Name: Miscellaneous_Devices.SchLib; Mounting Type: Surface Mount; Operating Temperature: -20°C ~ 70°C; Package / Case: 4-SMD, No Lead; Packaging: Cut Tape (CT); Part Status: Active; Pricing 1: 1=1.17, 10=1.037, 50=0.9696, 100=0.8569, 500=0.8118 (USD); Ratings: -; RoHS: RoHS Compliant; Size / Dimension: 0.126" L x 0.098" W (3.20mm x 2.50mm); Stock 1: 2201; Supplier 1: Digi-Key; Supplier Part Number 1: XC1150CT-ND; Type: MHz Crystal
X2 = XTAL: CRYSTAL 32.7680KHZ 12.5PF SMD; Manufacturer 1: Abracon LLC; Manufacturer Part Number 1: ABS06-32.768KHZ-T; ESR (Equivalent Series Resistance): 90 kOhm; Frequency Tolerance: ±20ppm; Operating Mode: Fundamental; Category: Crystals, Oscillators, Resonators; Component Kind: Standard; ComponentLink1Description: http://www.abracon.com/Resonators/ABS06.pdf; ComponentLink1URL: http://www.abracon.com/Resonators/ABS06.pdf; Frequency: 32.768kHz; Frequency Stability: -; Height - Seated (Max): 0.024" (0.60mm); Library Name: Miscellaneous_Devices.SchLib; Load Capacitance: 12.5pF; Mounting Type: Surface Mount; Operating Temperature: -40°C ~ 85°C; Package / Case: 2-SMD, No Lead; Packaging: Cut Tape (CT); Part Status: Active; Pricing 1: 1=0.74, 10=0.658, 50=0.615, 100=0.5434, 500=0.5148, 1000=0.429 (USD); Ratings: -; RoHS: RoHS Compliant; Size / Dimension: 0.079" L x 0.047" W (2.00mm x 1.20mm); Stock 1: 127000; Supplier 1: Digi-Key; Supplier Part Number 1: 535-10104-1-ND; Type: kHz Crystal (Tuning Fork)
